# Altium schematic sheet BLDC_Motor_Reverser.SchDoc(BLDC_Motor_Reverser)
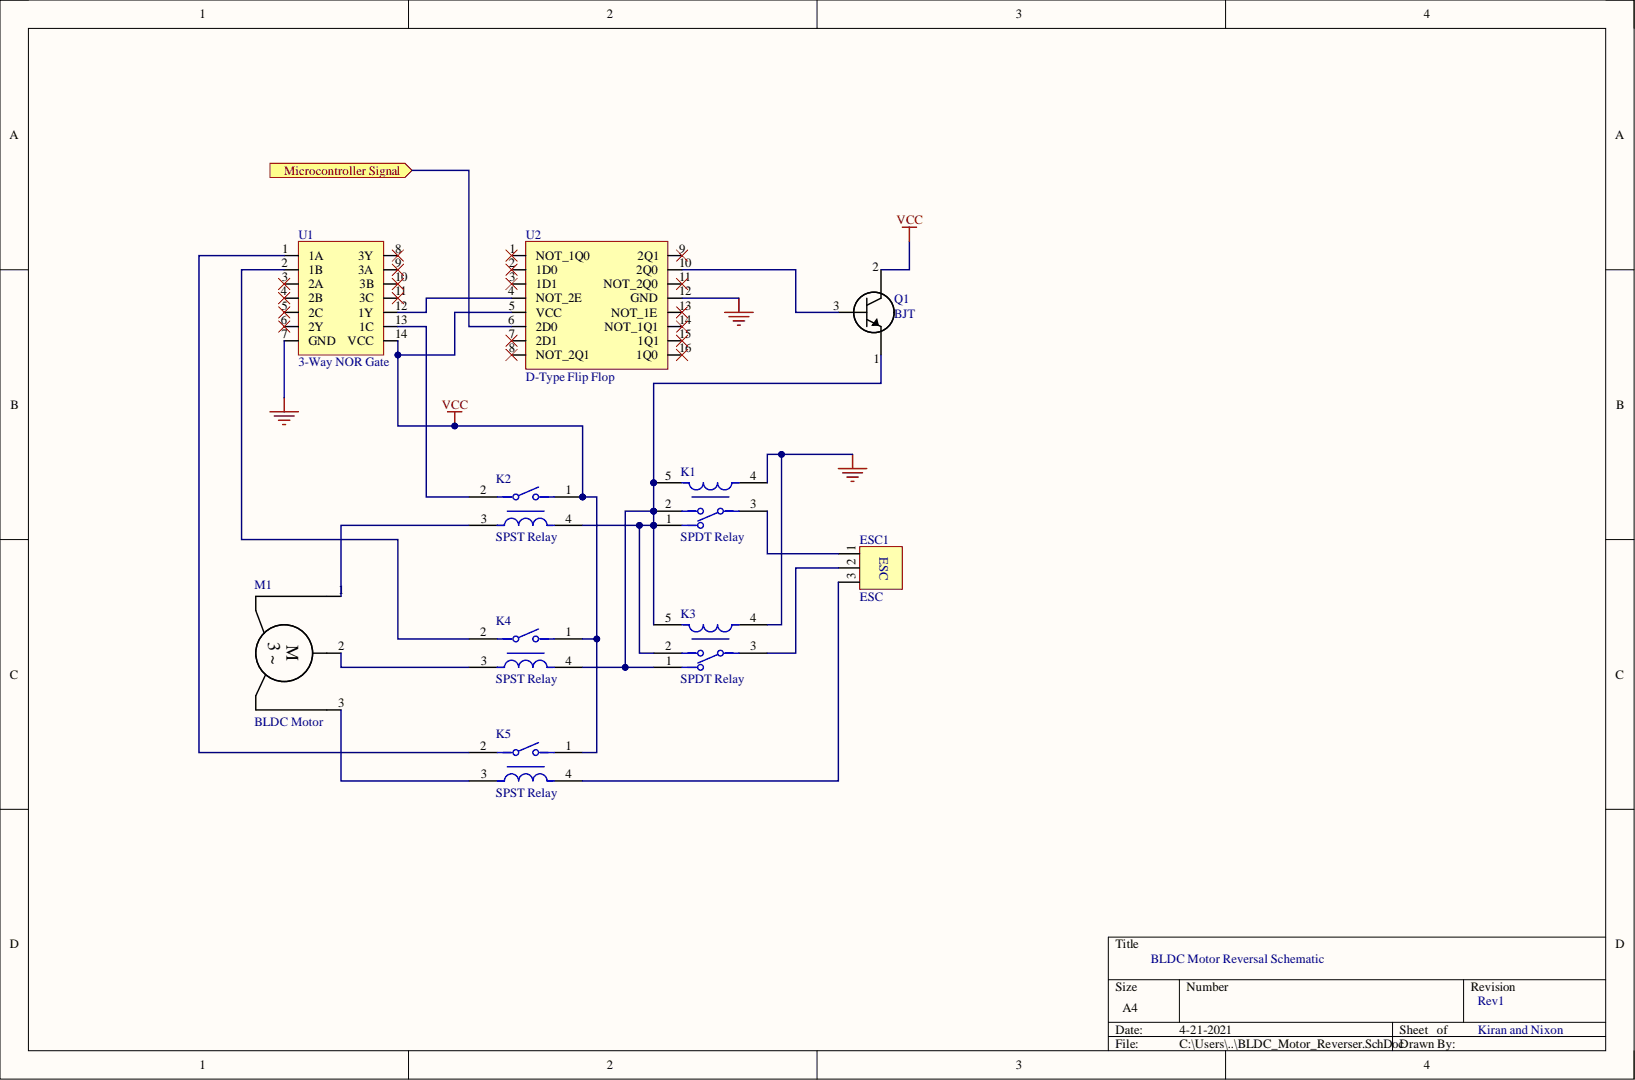
[diagram p0: BLDC_Motor_Reverser.SchDoc]

## Parts
ESC1  ESC
K1  SPDT Relay  Throw Configuration: SPDT  [FP-T9AS5D12-5-MFG]
K2  SPST Relay  Relay Function: SPST
K3  SPDT Relay  Throw Configuration: SPDT  [FP-T9AS5D12-5-MFG]
K4  SPST Relay  Relay Function: SPST
K5  SPST Relay  Relay Function: SPST
M1  BLDC Motor
Q1  BJT
U1  3-Way NOR Gate
U2  D-Type Flip Flop

## Ports
Microcontroller Signal

## Nets
GND: K1.4 K3.4 U1.7(GND) U2.12(GND)
VCC: K2.1 K4.1 K5.1 Q1.2 U1.14(VCC) U2.5(VCC)
Microcontroller Signal: U2.6(2D0) ; port Microcontroller Signal
NetESC1_1: ESC1.1 K1.3
NetESC1_2: ESC1.2 K3.3
NetESC1_3: ESC1.3 K5.4
NetK1_1: K1.1 K1.2 K1.5 K2.4 K3.1 K3.2 K3.5 K4.4 Q1.1
NetK2_2: K2.2 U1.13(1C)
NetK2_3: K2.3 M1.1
NetK4_2: K4.2 U1.2(1B)
NetK4_3: K4.3 M1.2
NetK5_2: K5.2 U1.1(1A)
NetK5_3: K5.3 M1.3
NetQ1_3: Q1.3 U2.10(2Q0)
NetU1_12: U1.12(1Y) U2.4(NOT_2E)

Not connected: U1 3(2A) 4(2B) 5(2C) 6(2Y) 8(3Y) 9(3A) 10(3B) 11(3C); U2 1(NOT_1Q0) 2(1D0) 3(1D1) 7(2D1) 8(NOT_2Q1) 9(2Q1) 11(NOT_2Q0) 13(NOT_1E) 14(NOT_1Q1) 15(1Q1) 16(1Q0)

## Library data of the parts
ESC1 = ESC: Electronics Speed Controller; Component Kind: Standard; Library Name: BLDC_Motor_Lib.SchLib
K1 K3 = T9AS5D12-5: RELAY GEN PURPOSE SPDT 20A 5V; Coil Current: 200mA; Coil Power: 1W; Coil Resistance: 25R; Coil Type: Non-Latching; Contact Voltage Rating (AC): 277V; Current Rating: 30A; Max Voltage Rating (DC): 28V; Operate Time: 15ms; Relay Type: General Purpose; Coil Voltage (DC): 5V; Component Kind: Standard; Contact Current Rating: 20A; Contact Material: Silver; Height: 20.4mm; Length: 32.5mm; Library Name: BLDC_Motor_Lib.SchLib; Max Operating Temperature: 85°C; Max Voltage Rating (AC): 277V; Min Operating Temperature: -55°C; Mount: Through Hole; Number of Pins: 5; Package Quantity: 50; Packaging: Bulk; Release Time: 15ms; RoHS Compliant: Yes; Series: T9A; Termination: PCB; Voltage Rating (DC): 5V; Weight: 0.918oz; Width: 27.4mm
K2 K4 K5 = JTN1AS-PA-F-DC12V: POWER RELAY SPST-NO 12VDC, 30A, PC BOARD; Manufacturer: Panasonic; Approvals: UL,CSA; Coil Current: 66.6mA; Coil Power: 800mW; Coil Resistance: 180R; Coil Type: Non-Latching; Coil Voltage (DC): 12V; Life (Hours): 100000Hour; Max Voltage Rating (AC): 277V; Operate Time: 20ms; Relay Type: General Purpose; Release Time: 10ms; Category: Signal Relays; Component Kind: Standard; ComponentLink1Description: https://datasheet.ciiva.com/19471/761141-19471062.pdf?src-supplier=Jameco; ComponentLink1URL: https://datasheet.ciiva.com/19471/761141-19471062.pdf?src-supplier=Jameco; ComponentLink2Description: https://datasheet.ciiva.com/30126/33ds-61212-en-jtn-30126555.pdf?src-supplier=Onlinecomponents.com; ComponentLink2URL: https://datasheet.ciiva.com/30126/33ds-61212-en-jtn-30126555.pdf?src-supplier=Onlinecomponents.com; ComponentLink3Description: https://datasheet.ciiva.com/8000/1848831-8000169.pdf?src-supplier=Element14; ComponentLink3URL: https://datasheet.ciiva.com/8000/1848831-8000169.pdf?src-supplier=Element14; ComponentLink4Description: https://datasheet.ciiva.com/46407/1794180-46407578.pdf?src-supplier=Element14; ComponentLink4URL: https://datasheet.ciiva.com/46407/1794180-46407578.pdf?src-supplier=Element14; Contact Current Rating: 30A; Contact Material: Tin,Silver; Contact Plating: Silver; Current Rating: 30A; Depth: 26.9mm; Height: 20.2mm; Lead Free: Lead Free; Length: 31.9mm; Library Name: BLDC_Motor_Lib.SchLib; Manufacturer Part Number: JTN1AS-PA-F-DC12V; Max Current Rating: 30A; Max Operating Temperature: 85°C; Min Operating Temperature: -55°C; Mount: Through Hole,PCB; Number of Pins: 4; Package Quantity: 50; Packaging: Bulk; Power Consumption: 800mW; Pricing 1: 1=3.98000, 10=3.72700, 25=3.31280, 50=3.14720, 100=2.98150, 250=2.65024, 500=2.48460, 1000=2.31896 (USD); Radiation Hardening: No; REACH SVHC: No SVHC; RoHS: Yes; Series: JT-N; Stock 1: 28244; Supplier 1: Digi-Key; Supplier Part Number 1: 255-1719-ND; Termination: PC Pin; Throw Configuration: SPST; Voltage Rating (AC): 277V; Weight: 25g
M1 = BLDC_Motor: Component Kind: Standard; Library Name: BLDC_Motor_Lib.SchLib
Q1 = KSD1616AGBU: Trans GP BJT NPN 60V 1A 3-Pin TO-92 Bulk; Manufacturer: ON Semiconductor / Fairchild; Collector Base Voltage (VCBO): 120V; Collector-emitter Voltage-Max: 300mV; Element Configuration: Single; Emitter Base Voltage (VEBO): 6V; Frequency: 160MHz; hFE Min: 135; Max Power Dissipation: 750mW; Number of Elements: 1; Case/Package: TO-92; Category: BJTs; Collector Emitter Breakdown Voltage: 60V; Collector Emitter Voltage (VCEO): 60V; Component Kind: Standard; ComponentLink1Description: https://datasheet.ciiva.com/4721/ksd1616a-57831-4721507.pdf?src-supplier=Mouser; ComponentLink1URL: https://datasheet.ciiva.com/4721/ksd1616a-57831-4721507.pdf?src-supplier=Mouser; ComponentLink2Description: https://datasheet.ciiva.com/979/ksd1616a-979225.pdf?src-supplier=Digi-Key; ComponentLink2URL: https://datasheet.ciiva.com/979/ksd1616a-979225.pdf?src-supplier=Digi-Key; ComponentLink3Description: https://datasheet.ciiva.com/11207/ksd1616-11207770.pdf?src-supplier=Avnet; ComponentLink3URL: https://datasheet.ciiva.com/11207/ksd1616-11207770.pdf?src-supplier=Avnet; ComponentLink4Description: https://datasheet.ciiva.com/46415/1863493-46415547.pdf?src-supplier=Element14; ComponentLink4URL: https://datasheet.ciiva.com/46415/1863493-46415547.pdf?src-supplier=Element14; ComponentLink5Description: https://datasheet.ciiva.com/26114/ksd1616-26114015.pdf?src-supplier=Avnet+Europe; ComponentLink5URL: https://datasheet.ciiva.com/26114/ksd1616-26114015.pdf?src-supplier=Avnet+Europe; Current Rating: 1A; Gain Bandwidth Product: 160MHz; Lead Free: Lead Free; Library Name: BLDC_Motor_Lib.SchLib; Manufacturer Part Number: KSD1616AGBU; Max Collector Current: 1A; Max Operating Temperature: 150°C; Min Operating Temperature: -55°C; Mount: Through Hole; Number of Pins: 3; Package Quantity: 1000; Packaging: Bulk; Polarity: NPN; Power Dissipation: 750mW; Pricing 1: 1=0.50000, 10=0.36900, 25=0.32280, 100=0.20890, 500=0.13832, 1000=0.10605, 2500=0.09222, 5000=0.08300 (USD); Radiation Hardening: No; REACH SVHC: No SVHC; RoHS: Yes; RoHS Compliant: Yes; Series: KSD1616; Stock 1: 15787; Supplier 1: Digi-Key; Supplier Part Number 1: KSD1616AGBU-ND; Transition Frequency: 160MHz; Voltage Rating (DC): 60V; Weight: 0.179g
U1 = CD74HC27E: NOR Gate 3-Element 3-IN CMOS 14-Pin PDIP Tube; Manufacturer: Texas Instruments; High Level Output Current: -5.2mA; Low Level Output Current: 5.2mA; Max Supply Voltage: 6V; Min Supply Voltage: 2V; Number of Bits: 3; Number of Output Lines: 1; Propagation Delay: 25ns; Quiescent Current: 2uA; Schmitt Trigger Input: No; Case/Package: PDIP; Category: Logic Gates; Component Kind: Standard; ComponentLink1Description: https://datasheet.ciiva.com/15090/getdatasheetpartid-40537-15090126.pdf?src-supplier=Verical; ComponentLink1URL: https://datasheet.ciiva.com/15090/getdatasheetpartid-40537-15090126.pdf?src-supplier=Verical; ComponentLink2Description: https://datasheet.ciiva.com/41455/001015738-da-01-en-ic-3-in-nor-gate-t-cd74hc27e-pdip-14-tid-41455649.pdf?src-supplier=Conrad; ComponentLink2URL: https://datasheet.ciiva.com/41455/001015738-da-01-en-ic-3-in-nor-gate-t-cd74hc27e-pdip-14-tid-41455649.pdf?src-supplier=Conrad; ComponentLink3Description: https://datasheet.ciiva.com/1869/cd74hct27-1869583.pdf?src-supplier=Digi-Key; ComponentLink3URL: https://datasheet.ciiva.com/1869/cd74hct27-1869583.pdf?src-supplier=Digi-Key; ComponentLink4Description: https://datasheet.ciiva.com/26242/cd74hc27-26242534.pdf?src-supplier=Avnet+Europe; ComponentLink4URL: https://datasheet.ciiva.com/26242/cd74hc27-26242534.pdf?src-supplier=Avnet+Europe; ComponentLink5Description: https://datasheet.ciiva.com/7782/1762827-7782951.pdf?src-supplier=Element14; ComponentLink5URL: https://datasheet.ciiva.com/7782/1762827-7782951.pdf?src-supplier=Element14; Contact Plating: Gold; Height: 4.57mm; Lead Free: Lead Free; Length: 19.69mm; Library Name: BLDC_Motor_Lib.SchLib; Logic Function: NOR GATE; Manufacturer Part Number: CD74HC27E; Max Operating Temperature: 125°C; Max Output Current: 5.2mA; Min Operating Temperature: -55°C; Mount: Through Hole; Number of Circuits: 3; Number of Elements: 3; Number of Gates: 3; Number of Input Lines: 3; Number of Inputs: 3; Number of Outputs: 1; Number of Pins: 14; Output Current: 5.2mA; Package Quantity: 25; Packaging: Rail/Tube; Pricing 1: 1=0.62000, 10=0.54200, 25=0.50920, 100=0.41570, 250=0.38612, 500=0.32860, 1000=0.26288, 2500=0.25885 (USD); Radiation Hardening: No; REACH SVHC: No SVHC; RoHS: Yes; RoHS Compliant: Yes; Stock 1: 1970; Supplier 1: Digi-Key; Supplier Part Number 1: 296-12802-5-ND; Termination: Through Hole; Turn-On Delay Time: 25ns; Weight: 0.032734oz; Width: 6.6mm
U2 = CD74HC75E: Latch Transparent 4-CH D-Type 16-Pin PDIP Tube D-Type Flip Flop; Manufacturer: Texas Instruments; High Level Output Current: -5.2mA; Independent Circuits: 2; Logic Function: Latch,D-Type; Low Level Output Current: 5.2mA; Max Supply Voltage: 6V; Min Supply Voltage: 2V; Number of Bits: 4; Polarity: Non-Inverting; Propagation Delay: 195ns; Quiescent Current: 4uA; Turn-On Delay Time: 10ns; Case/Package: PDIP; Category: Latches and Flip Flops; Component Kind: Standard; ComponentLink1Description: https://datasheet.ciiva.com/15087/getdatasheetpartid-40712-15087997.pdf?src-supplier=Verical; ComponentLink1URL: https://datasheet.ciiva.com/15087/getdatasheetpartid-40712-15087997.pdf?src-supplier=Verical; ComponentLink2Description: https://datasheet.ciiva.com/1882/cd74hc75-1882391.pdf?src-supplier=Digi-Key; ComponentLink2URL: https://datasheet.ciiva.com/1882/cd74hc75-1882391.pdf?src-supplier=Digi-Key; ComponentLink3Description: https://datasheet.ciiva.com/14288/cd74hct75-14288102.pdf?src-supplier=Avnet; ComponentLink3URL: https://datasheet.ciiva.com/14288/cd74hct75-14288102.pdf?src-supplier=Avnet; ComponentLink4Description: https://datasheet.ciiva.com/1508/1860868-1508312.pdf?src-supplier=Element14; ComponentLink4URL: https://datasheet.ciiva.com/1508/1860868-1508312.pdf?src-supplier=Element14; ComponentLink5Description: https://datasheet.ciiva.com/26187/cd74hct75-26187738.pdf?src-supplier=Avnet+Europe; ComponentLink5URL: https://datasheet.ciiva.com/26187/cd74hct75-26187738.pdf?src-supplier=Avnet+Europe; ComponentLink6Description: https://datasheet.ciiva.com/41455/001015818-da-01-en-ic-dual-2-bit-tran-cd74hc75e-pdip-16-tid-41455802.pdf?src-supplier=Conrad; ComponentLink6URL: https://datasheet.ciiva.com/41455/001015818-da-01-en-ic-dual-2-bit-tran-cd74hc75e-pdip-16-tid-41455802.pdf?src-supplier=Conrad; Contact Plating: Gold; Height: 4.57mm; Lead Free: Lead Free; Length: 19.3mm; Library Name: BLDC_Motor_Lib.SchLib; Manufacturer Part Number: CD74HC75E; Max Operating Temperature: 125°C; Min Operating Temperature: -55°C; Mount: Through Hole; Number of Circuits: 2; Number of Elements: 2; Number of Input Lines: 4; Number of Output Lines: 4; Number of Pins: 16; Output Current: 5.2mA; Package Quantity: 25; Packaging: Rail/Tube; Pricing 1: 1=0.94000, 10=0.84100, 25=0.79840, 100=0.65580, 250=0.61304, 500=0.54176, 1000=0.44923 (USD); Radiation Hardening: No; REACH SVHC: No SVHC; RoHS: Yes; RoHS Compliant: Yes; Series: 74HC; Stock 1: 1957; Supplier 1: Digi-Key; Supplier Part Number 1: 296-9240-5-ND; Technology: CMOS; Termination: Through Hole; Weight: 0.03357oz; Width: 6.35mm
